# Altium schematic sheet Power_Input.SchDoc(Power_Input)
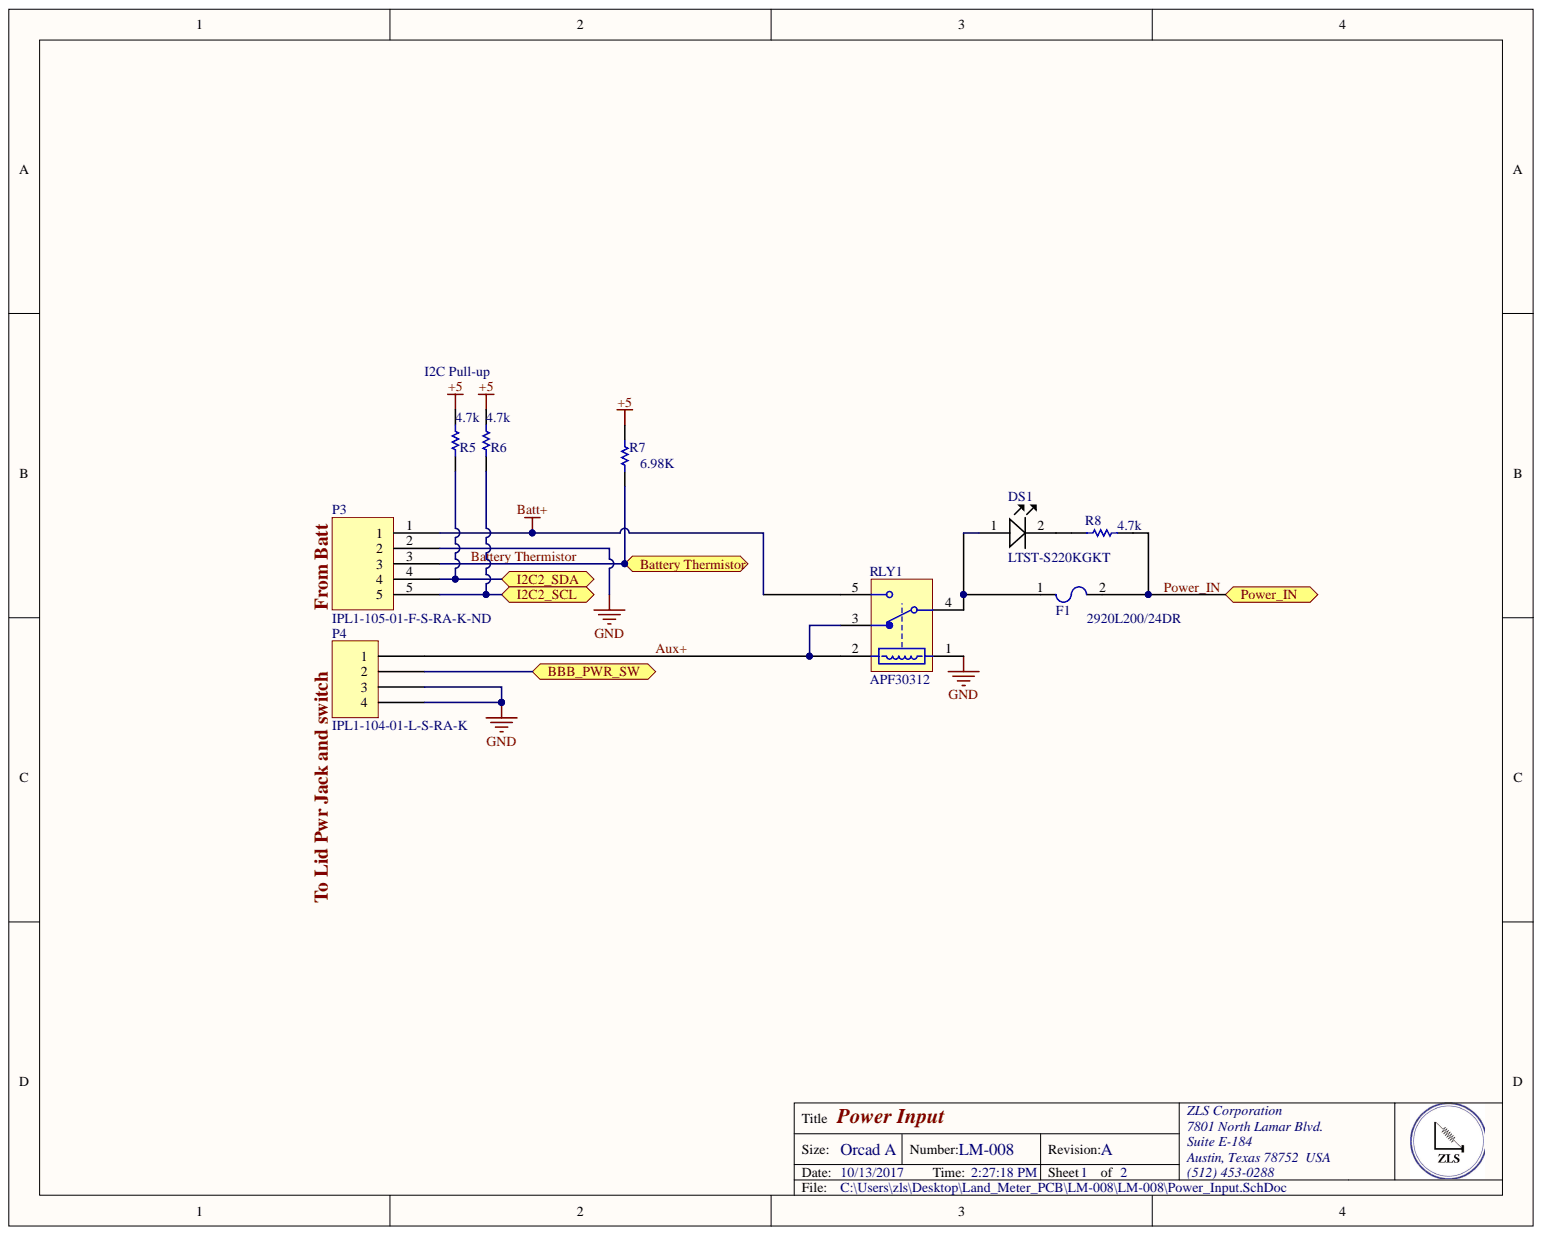
[diagram p0: Power_Input.SchDoc]

## Parts
DS1  Manufacturer Part Number: LTST-S220KGKT  [0805C_L]  (hidden comment: Lite-On LTST-S220KGKT Green LED, 571 nm 2012 (0805) Side View, Rectangle Lens SMD package)
F1  PCT  [CAPC7451X12N]  (value not drawn)
P3  IPL1-105-01-F-S-RA  Supplier Part Number 1: IPL1-105-01-F-S-RA-K-ND  [IPL1-105-01-F-S-RA]
P4  IPL1-104-01-L-S-RA-K  [IPL1-104-01-L-S-RA-K]
R5  Resistance (Ohms): 4.7k  [RESC0805(2012)_N]  (hidden comment: 3K74 0.125W 1% 0805 (2012 Metric)  SMD)
R6  Resistance (Ohms): 4.7k  [RESC0805(2012)_N]  (hidden comment: 3K74 0.125W 1% 0805 (2012 Metric)  SMD)
R7  6.98K  [RESC0402(1005)_L]
R8  Resistance (Ohms): 4.7k  [RESC0805(2012)_M]  (hidden comment: RES SMD 4.7K OHM 1% 1/8W 0805)
RLY1  APF30312  [PNSC-PF-5-2800x500x1500_V]

## Ports
Battery Thermistor, BBB_PWR_SW, I2C2_SCL, I2C2_SDA, Power_IN

## Nets
+5: R5.2 R6.2 R7.2
Batt+: P3.1 RLY1.5
GND: P3.2 P4.3 P4.4 RLY1.1
Aux+: P4.1 RLY1.2 RLY1.3
Battery Thermistor: P3.3 R7.1 ; port Battery Thermistor
BBB_PWR_SW: P4.2 ; port BBB_PWR_SW
I2C2_SCL: P3.5 R6.1 ; port I2C2_SCL
I2C2_SDA: P3.4 R5.1 ; port I2C2_SDA
Power_IN: F1.2 R8.1 ; port Power_IN
NetDS1_1: DS1.1 F1.1 RLY1.4
NetDS1_2: DS1.2 R8.2

## Library data of the parts
DS1 = LED A/K: Manufacturer: Vishay / Lite-On; Wavelength: 574nm; Viewing Angle: 130°; Test Current: 20mA; Power Rating: 75mW; Power Dissipation: 75W; Number of LEDs: 1; Luminous Intensity: 35mcd; Lens Transparency: Clear; Lens Style: Diffused,Colorless; Lens Colour: Colorless; Forward Voltage: 2V; Dominant Wavelength: 568nm; Width: 1mm; Supplier Part Number 2: 2062310; Supplier Part Number 1: 160-1468-1-ND; Supplier 2: Farnell; Supplier 1: Digi-Key; Stock 2: 2271; Stock 1: 74800; RoHS: YES; Radiation Hardening: No; Pricing 2: 1=0.325, 10=0.317, 100=0.23, 200=0.228, 400=0.182, 900=0.147, 3000=0.114, 9000=0.107 (GBP); Pricing 1: 1=0.29, 10=0.212, 25=0.1564, 100=0.1117, 250=0.0759, 500=0.067, 1000=0.0525 (USD); Packaging: Tape and Reel; Package Quantity: 4000; Number of Pins: 2; Number of Elements: 1; Mount: Surface Mount; Min Operating Temperature: -55°C; Max Operating Temperature: 85°C; Length: 2.1mm; Lead Free: Lead Free; Illumination Colour: Green; Height: 0.6mm; Forward Current: 20mA; Description: Lite-On LTST-S220KGKT Green LED, 571 nm 2012 (0805) Side View, Rectangle Lens SMD package; Depth: 0.6mm; ComponentLink8URL: http://www.farnell.com/datasheets/693084.pdf; ComponentLink8Description: http://www.farnell.com/datasheets/693084.pdf; ComponentLink7URL: http://www.farnell.com/cad/2124774.pdf; ComponentLink7Description: http://www.farnell.com/cad/2124774.pdf; ComponentLink6URL: https://datasheet.ciiva.com/16379/ltst-s220kgkt-16379082.pdf; ComponentLink6Description: https://datasheet.ciiva.com/16379/ltst-s220kgkt-16379082.pdf; ComponentLink5URL: https://datasheet.ciiva.com/2110/s-110-ltst-s220kgkt-2110071.pdf; ComponentLink5Description: https://datasheet.ciiva.com/2110/s-110-ltst-s220kgkt-2110071.pdf; ComponentLink4URL: https://datasheet.ciiva.com/12400/100-ltst-s220kgkt-59602-12400886.pdf; ComponentLink4Description: https://datasheet.ciiva.com/12400/100-ltst-s220kgkt-59602-12400886.pdf; ComponentLink3URL: https://datasheet.ciiva.com/2110/s-110-ltst-s220kgkt-2110070.pdf; ComponentLink3Description: https://datasheet.ciiva.com/2110/s-110-ltst-s220kgkt-2110070.pdf; ComponentLink2URL: https://datasheet.ciiva.com/2110/s-110-ltst-s220kgkt-2110069.pdf; ComponentLink2Description: https://datasheet.ciiva.com/2110/s-110-ltst-s220kgkt-2110069.pdf; ComponentLink1URL: https://datasheet.ciiva.com/11775/0900766b80dc0b70-11775441.pdf; ComponentLink1Description: https://datasheet.ciiva.com/11775/0900766b80dc0b70-11775441.pdf; Component Kind: Standard; Colour: Green; Case Code (Metric): 2012; Case Code (Imperial): 0805; Case/Package: 0805
P4 = IPL1-104-01-L-S-RA-K: Manufacturer: Samtec Inc.; Voltage Rating: 675VAC, 954VDC; Style: Board to Cable/Wire; Shrouding: Shrouded - 4 Wall; Pitch - Mating: 0.100" (2.54mm); Number of Positions Loaded: All; Number of Positions: 4; Material Flammability Rating: UL94 V-0; Contact Length - Post: 0.085" (2.16mm); Contact Length - Mating: 0.215" (5.46mm); Connector Type: Header; Termination: Solder; Supplier Part Number 1: SAM9597-ND; Supplier 1: Digi-Key; Stock 1: 265; Row Spacing - Mating: -; RoHS: RoHS Compliant; Pricing 1: 1=2.53, 10=2.424, 100=2.02, 500=1.717, 1000=1.4645, 5000=1.313 (USD); Part Status: Active; Packaging: Tube; Overall Contact Length: -; Operating Temperature: -55°C ~ 125°C; Number of Rows: 1; Mounting Type: Through Hole, Right Angle; Mated Stacking Heights: -; Manufacturer Part Number: IPL1-104-01-L-S-RA-K; Insulation Material: Liquid Crystal Polymer (LCP); Insulation Height: 0.240" (6.10mm); Insulation Color: Natural; Ingress Protection: -; Features: -; Fastening Type: Locking Ramp; Description: IPL1-104-01-L-S-RA-K; Current Rating: -; Contact Type: Male Pin; Contact Shape: Square; Contact Material: Phosphor Bronze; Contact Finish Thickness - Post: -; Contact Finish Thickness - Mating: 10µin (0.25µm); Contact Finish - Post: Tin; Contact Finish - Mating: Gold; ComponentLink1URL: http://suddendocs.samtec.com/prints/ipl1-1xx-xx-xxx-s-xx-x-xx-mkt.pdf; ComponentLink1Description: http://suddendocs.samtec.com/prints/ipl1-1xx-xx-xxx-s-xx-x-xx-mkt.pdf; Component Kind: Standard; Category: Connectors, Interconnects; Applications: -; Library Name: ZLS_Schematic_lib.SchLib
R5 R6 = CMP-1013-00574-1: Manufacturer Part Number: CRCW08054K70FKEA; Manufacturer: Vishay Dale; Temperature Coefficient: ±100ppm/°C; Power (Watts): 0.125W, 1/8W; Tolerance: ±1%; Supplier Part Number 1: 541-4.70KCDKR-ND; Supplier Device Package: 0805; Supplier 1: Digi-Key; Stock 1: 0; Size / Dimension: 0.079" L x 0.049" W (2.00mm x 1.25mm); RoHS: RoHS Compliant; Pricing 1: 1=0.1, 10=0.044, 100=0.0178, 1000=0.00802, 2500=0.00696 (USD); Part Status: Active; Packaging: Digi-Reel®; Package / Case: 0805 (2012 Metric); Operating Temperature: -55°C ~ 155°C; Library Name: Altium Content Vault; Height - Seated (Max): 0.020" (0.50mm); Features: Automotive AEC-Q200; Failure Rate: -; Description: 3K74 0.125W 1% 0805 (2012 Metric)  SMD; Composition: Thick Film; ComponentLink1URL: http://www.vishay.com/docs/20035/dcrcwe3.pdf; ComponentLink1Description: http://www.vishay.com/docs/20035/dcrcwe3.pdf; Component Kind: Standard; Category: Resistors
R7 = CMP-1011-00927-1: RES SMD 6.98K OHM 1% 1/16W 0402; Manufacturer Part Number: RC0402FR-076K98L; Manufacturer: Yageo; Temperature Coefficient: ±100ppm/°C; Power (Watts): 0.063W, 1/16W; Tolerance: ±1%; Supplier Part Number 1: YAG3220CT-ND; Supplier Device Package: 0402; Supplier 1: Digi-Key; Stock 1: 182255; Size / Dimension: 0.039" L x 0.020" W (1.00mm x 0.50mm); RoHS: RoHS Compliant; Pricing 1: 1=0.1, 10=0.014, 100=0.0055, 1000=0.00247, 2500=0.00214, 5000=0.00177 (USD); Part Status: Active; Packaging: Cut Tape (CT); Package / Case: 0402 (1005 Metric); Operating Temperature: -55°C ~ 155°C; Library Name: Altium Content Vault; Height - Seated (Max): 0.016" (0.40mm); Features: Moisture Resistant; Failure Rate: -; Composition: Thick Film; ComponentLink1URL: http://www.yageo.com/documents/recent/PYu-RC_Group_51_RoHS_L_7.pdf; ComponentLink1Description: http://www.yageo.com/documents/recent/PYu-RC_Group_51_RoHS_L_7.pdf; Component Kind: Standard; Category: Resistors
R8 = CMP-1013-00017-1: Manufacturer Part Number: CRCW08054K70FKEA; Manufacturer: Vishay Dale; Temperature Coefficient: ±100ppm/°C; Power (Watts): 0.125W, 1/8W; Tolerance: ±1%; Supplier Part Number 1: 541-4.70KCDKR-ND; Supplier Device Package: 0805; Supplier 1: Digi-Key; Stock 1: 0; Size / Dimension: 0.079" L x 0.049" W (2.00mm x 1.25mm); RoHS: RoHS Compliant; Pricing 1: 1=0.1, 10=0.044, 100=0.0178, 1000=0.00802, 2500=0.00696 (USD); Part Status: Active; Packaging: Digi-Reel®; Package / Case: 0805 (2012 Metric); Operating Temperature: -55°C ~ 155°C; Library Name: Altium Content Vault; Height - Seated (Max): 0.020" (0.50mm); Features: Automotive AEC-Q200; Failure Rate: -; Description: RES SMD 4.7K OHM 1% 1/8W 0805; Composition: Thick Film; ComponentLink1URL: http://www.vishay.com/docs/20035/dcrcwe3.pdf; ComponentLink1Description: http://www.vishay.com/docs/20035/dcrcwe3.pdf; Component Kind: Standard; Category: Resistors
RLY1 = CMP-1503-00270-1: PF Relays, 12 V Coil Voltage, 170 mW Nominal Operating Power, -40 to 85 degC, 5-Pin PF, Tube, Case, RoHS; Manufacturer: Panasonic; Pb-Free: True; Nominal Operating Power: 170 mW; Mechanical Life(Min.operation): 5x10^6; Max Switching Voltage: 250 V AC; Max Switching Current: 6 A; Electrical Life: 5x10^4 (N.O.), 3x10^4 (N.C.); Coil Voltage: 12 V; Series: PF Relays; RoHS: True; PartNumber: APF30312; Packing: Tube, Case; PackageVersion: ASCTB205E, 02/2014; PackageReference: PF-5-2800x500x1500; PackageDescription: 5-Pin Through Hole Terminal Package, Body 28 x 5 mm; Mounting Technology: Through Hole; Library Name: Altium Content Vault; DatasheetVersion: 201402-T; ComponentLink3URL: http://www3.panasonic.biz/ac/e_download/control/relay/power/catalog/mech_eng_pf.pdf?f_cd=300412; ComponentLink3Description: Package Specification; ComponentLink2URL: http://www3.panasonic.biz/ac/e_download/control/relay/power/catalog/mech_eng_pf.pdf?f_cd=300412; ComponentLink2Description: Datasheet; ComponentLink1URL: http://www3.panasonic.biz/ac/ae/index.jsp; ComponentLink1Description: Manufacturer URL; Component Kind: Standard
F1 = PCT: Component Kind: Standard; Library Name: ZLS_Schematic_lib.SchLib
P3 = IPL1-105-01-F-S-RA: Supplier 1: Digi-Key; Component Kind: Standard; Library Name: ZLS_Schematic_lib.SchLib
